# Altium schematic sheet HW4.SchDoc(HW4)
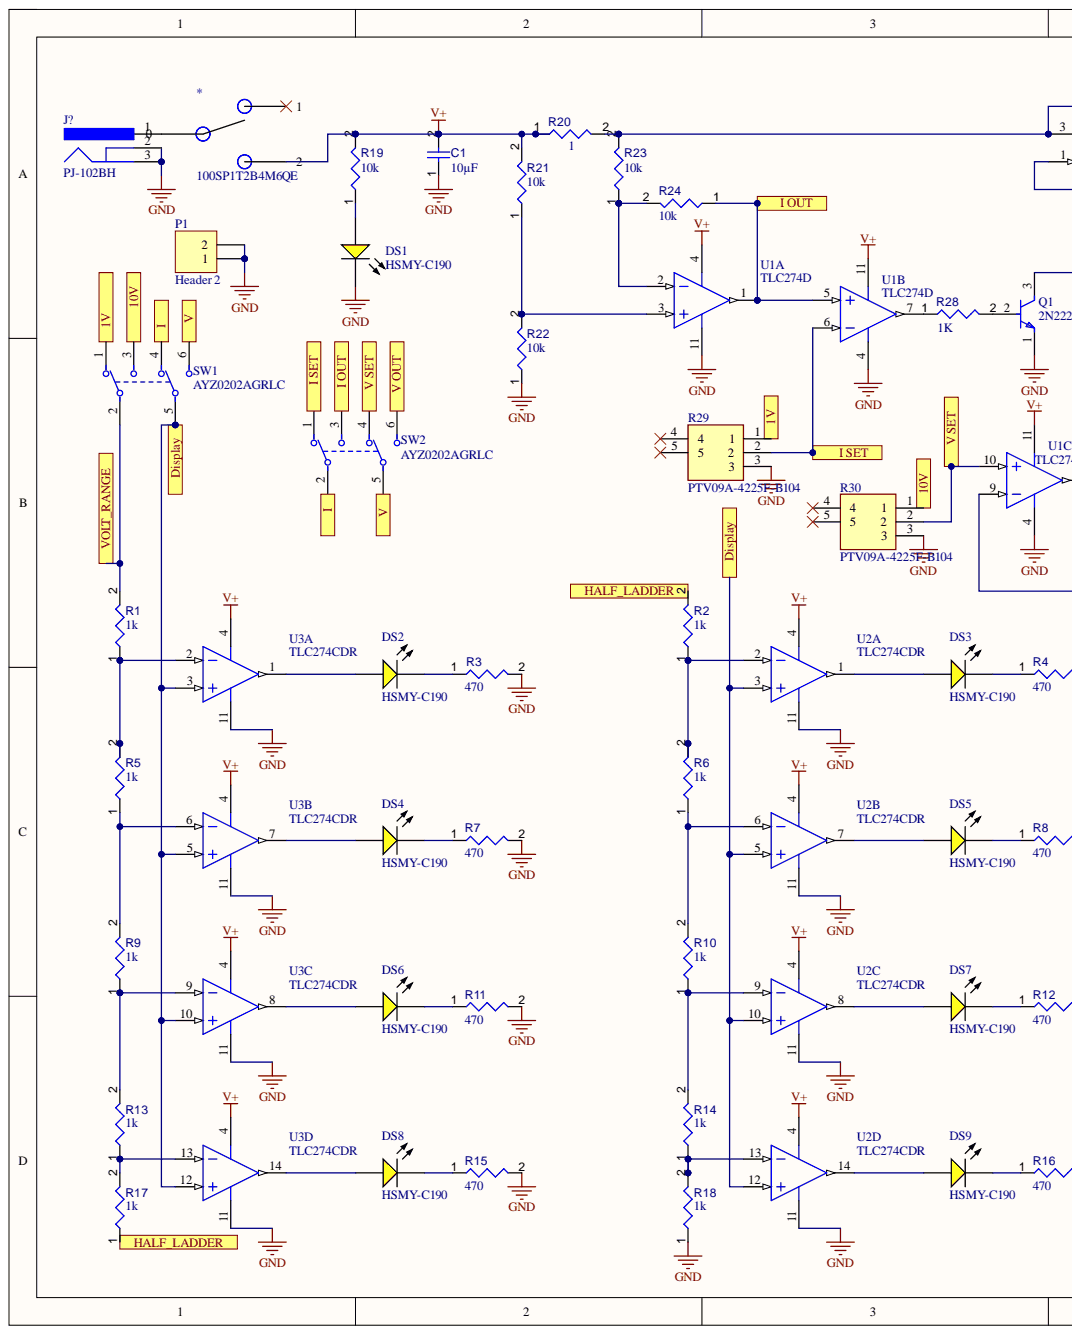
[diagram p0: HW4.SchDoc, left]
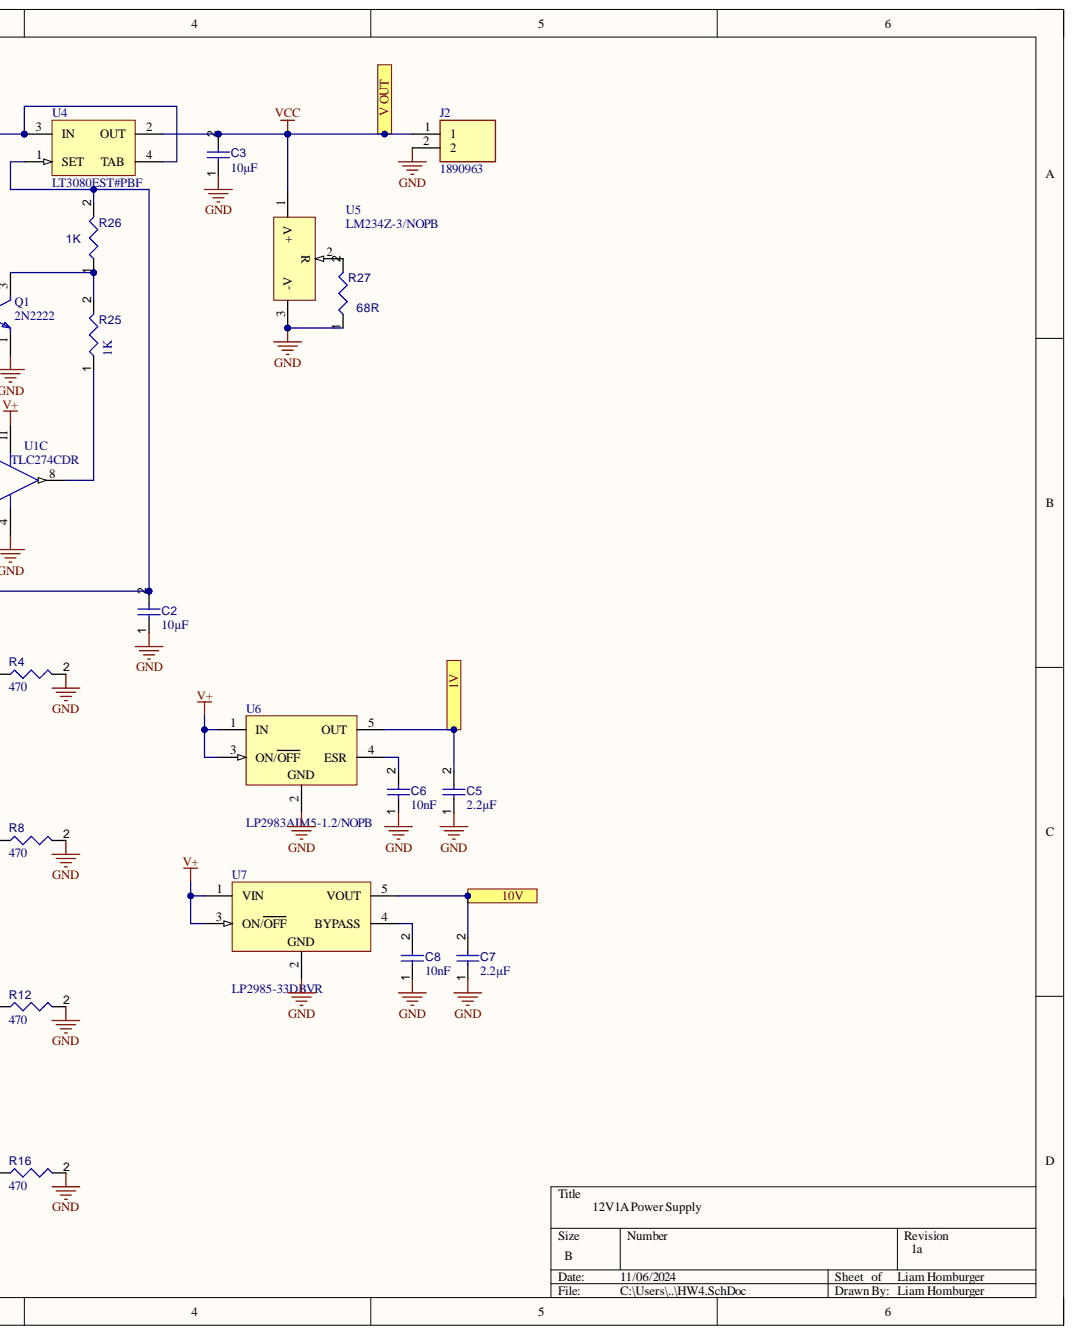
[diagram p1: HW4.SchDoc, right]

## Parts
*  100SP1T2B4M6QE  [100SP1T2B4M6QE-Footprint-1]
C1  value 10µF  [FP-0805-L_2_0_0_2-W_1_25-MFG]  (hidden comment: GMK212BBJ106KG-T)
C2  value 10µF  [FP-0805-L_2_0_0_2-W_1_25-MFG]  (hidden comment: GMK212BBJ106KG-T)
C3  value 10µF  [FP-0805-L_2_0_0_2-W_1_25-MFG]  (hidden comment: GMK212BBJ106KG-T)
C5  value 2.2µF  [Capacitor 0805]  (hidden comment: VJ0805G225KXJTW1BC)
C6  10nf  [Capacitor 0805]
C7  value 2.2µF  [Capacitor 0805]  (hidden comment: VJ0805G225KXJTW1BC)
C8  10nf  [Capacitor 0805]
DS1  HSMY-C190  [AVAG-HSMX-C190_V]
DS2  HSMY-C190  [AVAG-HSMX-C190_V]
DS3  HSMY-C190  [AVAG-HSMX-C190_V]
DS4  HSMY-C190  [AVAG-HSMX-C190_V]
DS5  HSMY-C190  [AVAG-HSMX-C190_V]
DS6  HSMY-C190  [AVAG-HSMX-C190_V]
DS7  HSMY-C190  [AVAG-HSMX-C190_V]
DS8  HSMY-C190  [AVAG-HSMX-C190_V]
DS9  HSMY-C190  [AVAG-HSMX-C190_V]
J2  1890963  [1890963]
J?  PJ-102BH  [CUI-PJ-102BH_V]
P1  Header 2  [HDR1X2]
Q1  2N2222  [FP-JAN2N2222A-MFG]
R1  value 1k  [RESC2013X60X40LL20T25_A]  (hidden comment: ERA-6AEB103V)
R2  value 1k  [RESC2013X60X40LL20T25_A]  (hidden comment: ERA-6AEB103V)
R3  value 470  [RESC2013X50X30ML20T20]  (hidden comment: CRCW0805470RFKEA)
R4  value 470  [RESC2013X50X30ML20T20]  (hidden comment: CRCW0805470RFKEA)
R5  value 1k  [RESC2013X60X40LL20T25_A]  (hidden comment: ERA-6AEB103V)
R6  value 1k  [RESC2013X60X40LL20T25_A]  (hidden comment: ERA-6AEB103V)
R7  value 470  [RESC2013X50X30ML20T20]  (hidden comment: CRCW0805470RFKEA)
R8  value 470  [RESC2013X50X30ML20T20]  (hidden comment: CRCW0805470RFKEA)
R9  value 1k  [RESC2013X60X40LL20T25_A]  (hidden comment: ERA-6AEB103V)
R10  value 1k  [RESC2013X60X40LL20T25_A]  (hidden comment: ERA-6AEB103V)
R11  value 470  [RESC2013X50X30ML20T20]  (hidden comment: CRCW0805470RFKEA)
R12  value 470  [RESC2013X50X30ML20T20]  (hidden comment: CRCW0805470RFKEA)
R13  value 1k  [RESC2013X60X40LL20T25_A]  (hidden comment: ERA-6AEB103V)
R14  value 1k  [RESC2013X60X40LL20T25_A]  (hidden comment: ERA-6AEB103V)
R15  value 470  [RESC2013X50X30ML20T20]  (hidden comment: CRCW0805470RFKEA)
R16  value 470  [RESC2013X50X30ML20T20]  (hidden comment: CRCW0805470RFKEA)
R17  value 1k  [RESC2013X60X40LL20T25_A]  (hidden comment: ERA-6AEB103V)
R18  value 1k  [RESC2013X60X40LL20T25_A]  (hidden comment: ERA-6AEB103V)
R19  value 10k  [RESC2013X60X40LL20T25_A]  (hidden comment: ERA-6AEB103V)
R20  value 1  [FP-MCU0805-MFG]  (hidden comment: MCU08050Z0000ZP500)
R21  value 10k  [RESC2013X60X40LL20T25_A]  (hidden comment: ERA-6AEB103V)
R22  value 10k  [RESC2013X60X40LL20T25_A]  (hidden comment: ERA-6AEB103V)
R23  value 10k  [RESC2013X60X40LL20T25_A]  (hidden comment: ERA-6AEB103V)
R24  value 10k  [RESC2013X60X40LL20T25_A]  (hidden comment: ERA-6AEB103V)
R25  1 Kohm 0805  [Chip2PinSM127P600-8N]  (value not drawn)
R26  1K  [Chip2PinSM127P600-8N]
R27  68R  [Chip2PinSM127P600-8N]
R28  1 Kohm 0805  [Chip2PinSM127P600-8N]  (value not drawn)
R29  PTV09A-4225F-B104  [PTV09A4020FB103]
R30  PTV09A-4225F-B104  [PTV09A4020FB103]
SW1  AYZ0202AGRLC  [FP-AYZ0202AGRLC-MFG]
SW2  AYZ0202AGRLC  [FP-AYZ0202AGRLC-MFG]
U1  TLC274D  [D0014A_L]  (parts A,B,C)
U2  TLC274CDR  [D0014A_L]  (parts A,B,C,D)
U3  TLC274CDR  [D0014A_L]  (parts A,B,C,D)
U4  LT3080EST#PBF  [LT-ST-3-SOT-223_M]
U5  LM234Z-3/NOPB  [LP0003A]
U6  LP2983AIM5-1.2/NOPB  [MF05A_M]
U7  LP2985-33DBVR  [DBV0005A_L]

## Ports
1V, 10V, Display, HALF_LADDER, I, I OUT, I SET, V, V OUT, V SET, VOLT_RANGE

## Nets
GND: C1.1 C2.1 C3.1 C5.1 C6.1 C7.1 C8.1 DS1.2 J2.2 J?.2 J?.3 P1.1 P1.2 Q1.1 R3.2 R4.2 R7.2 R8.2 R11.2 R12.2 R15.2 R16.2 R18.1 R22.1 R27.1 R29.3 R30.3 U1.4 U1.11 U2.11(V+) U3.11(V+) U5.3 U6.2 U7.2
V+: C1.2 R19.2 R20.1 R21.2 U1.4 U1.11 U2.4 U3.4 U6.1(IN) U6.3(ON/OFF) U7.1(VIN) U7.3(ON/OFF)
1V: C5.2 R29.1 SW1.1 U6.5(OUT) ; port 1V
10V: C7.2 R30.1 SW1.3 U7.5(VOUT) ; port 10V
Display: SW1.5 U2.3 U2.5 U2.10 U2.12 U3.3 U3.5 U3.10 U3.12 ; port Display
HALF_LADDER: R2.2 R17.1 ; port HALF_LADDER
I: SW1.4 SW2.2 ; port I
I OUT: R24.1 SW2.3 U1.1 U1.5 ; port I OUT
I SET: R29.2 SW2.1 U1.6 ; port I SET
V: SW1.6 SW2.5 ; port V
V SET: R30.2 SW2.4 U1.10 ; port V SET
VCC: C3.2 J2.1 SW2.6 U4.2(OUT) U5.1 ; port V OUT
VOLT_RANGE: R1.2 SW1.2 ; port VOLT_RANGE
NetC2_2: C2.2 R26.2 U1.9 U4.1(SET)
NetC6_2: C6.2 U6.4(ESR)
NetC8_2: C8.2 U7.4(BYPASS)
NetDS1_1: DS1.1 R19.1
NetDS2_1: DS2.1 U3.1
NetDS2_2: DS2.2 R3.1
NetDS3_1: DS3.1 U2.1
NetDS3_2: DS3.2 R4.1
NetDS4_1: DS4.1 U3.7
NetDS4_2: DS4.2 R7.1
NetDS5_1: DS5.1 U2.7
NetDS5_2: DS5.2 R8.1
NetDS6_1: DS6.1 U3.8
NetDS6_2: DS6.2 R11.1
NetDS7_1: DS7.1 U2.8
NetDS7_2: DS7.2 R12.1
NetDS8_1: DS8.1 U3.14
NetDS8_2: DS8.2 R15.1
NetDS9_1: DS9.1 U2.14
NetDS9_2: DS9.2 R16.1
NetQ1_2: Q1.2 R28.2
NetQ1_3: Q1.3 R25.2 R26.1
NetR1_1: R1.1 R5.2 U3.2
NetR2_1: R2.1 R6.2 U2.2
NetR5_1: R5.1 R9.2 U3.6
NetR6_1: R6.1 R10.2 U2.6
NetR9_1: R9.1 R13.2 U3.9
NetR10_1: R10.1 R14.2 U2.9
NetR13_1: R13.1 R17.2 U3.13
NetR14_1: R14.1 R18.2 U2.13
NetR20_2: R20.2 R23.2 U4.3(IN) U4.4(TAB)
NetR21_1: R21.1 R22.2 U1.3
NetR23_1: R23.1 R24.2 U1.2
NetR25_1: R25.1 U1.8
NetR27_2: R27.2 U5.2
NetR28_1: R28.1 U1.7

Not connected: * 0 1 2; J? 1; R29 4 5; R30 4 5

## Library data of the parts
* = CMP-011-00006-1: Toggle Switch SPDT; Current Rating: 5A; Throw Configuration: Dual; Voltage Rating: 28V; Case/Package: SP100; Component Kind: Standard; Max Current Rating: 5A; Pins: 3; Library Name: Liam Personal
C1-C3 = CMP-001-00008-1: Ceramic Capacitor, Multilayer, Ceramic, 35V, 10% +Tol, 10% -Tol, X5R, 15% TC, 10uF, Surface Mount, 0805; Capacitor Type: Ceramic; Case/Package: 0805; Component Kind: Standard; Max Operating Temperature: 85°C; Min Operating Temperature: -55°C; Mounting Technology: Surface Mount; Pins: 2; RoHS Compliant: Yes; Library Name: Liam Personal
C5-C8 = CMP-001-00007-1: Ceramic Capacitor, Multilayer, Ceramic, 16V, 10% +Tol, 10% -Tol, X5R, 15% TC, 2.2uF, Surface Mount, 0805; Capacitor Type: Ceramic; Case/Package: 0805; Component Kind: Standard; Max Operating Temperature: 85°C; Min Operating Temperature: -55°C; Mounting Technology: Surface Mount; Pins: 2; RoHS Compliant: Yes; Library Name: Liam Personal
  C6 C8: Value: 10nF
DS1-DS9 = CMP-007-00000-1: Single Color LED, Yellow, Untinted Diffused, 1mm; Forward Current: 20mA; Forward Voltage: 2.6V; Component Kind: Standard; Max Operating Temperature: 85°C; Min Operating Temperature: -40°C; Mounting Technology: Surface Mount; Pins: 2; RoHS Compliant: Yes; Library Name: Liam Personal
J2 = CMP-004-000000-2: 2 port screw terminal block; Manufacturer_Name: Phoenix Contact; Current Rating: 16A; Number of Decks: 1; Number of Ways: 2; Safety Approval: UL,VDE,CUL,GOST; Voltage Rating: 400V; Wire Cross Section: 2.5mm2; Wire/Cable Gauge: 14AWG; Arrow Part Number: 1890963; Arrow Price/Stock: https://www.arrow.com/en/products/1890963/phoenix-contact?region=nac; Component Kind: Standard; Datasheet Link: http://www.phoenixcontact.com/de/produkte/1890963/pdf; Design Item ID: 1890963; Fastening Method: SCREW; Height: 15.15mm; Manufacturer_Part_Number: 1890963; Mounting Technology: Board; Mouser Part Number: 651-1890963; Mouser Price/Stock: https://www.mouser.co.uk/ProductDetail/Phoenix-Contact/1890963?qs=5W%252BNKB25SvKX6KIA0xFJOA%3D%3D; Number of Rows: 1; Reach SVHC Compliant: No; RoHS Compliant: Yes; Source: SamacSys.SchLib; Terminal and Terminal Block Type: MODULAR TERMINAL BLOCK; Library Name: Liam Personal
J? = CMP-00030-00001-1: DC Power Plug or Jack; Component Kind: Standard; Library Name: Liam Personal
P1 = CMP-002-00098-1: Header, 2-Pin; Component Kind: Standard; Component Type: Standard; Design Item ID: Header 2; LatestRevisionDate: 17-Jul-2002; LatestRevisionNote: Re-released for DXP Platform.; Pins: 2; Signal Integrity: Connector; Source: Miscellaneous Connectors.SchLib; Library Name: Liam Personal; Publisher: Altium Limited
Q1 = CMP-013-00004-1: Small Signal Bipolar Transistor, 0.8A I(C), 50V V(BR)CEO, 1-Element, NPN, Silicon, TO-206AA; Component Kind: Standard; Library Name: Liam Personal
R1 R2 R5 R6 R9 R10 R13 R14 R17-R19 R21-R24 = CMP-009-00001-1: Fixed Resistor, Thin Film, 0.125W, 10000ohm, 100V, 0.1% +/-Tol, 25ppm/Cel, Surface Mount, 0805; Power: 125mW; Case/Package: 0805; Component Kind: Standard; Max Operating Temperature: 155°C; Min Operating Temperature: -55°C; Mounting Technology: Surface Mount; Pins: 2; RoHS Compliant: Yes; Tolerance: 0.1%; Library Name: Liam Personal
R3 R4 R7 R8 R11 R12 R15 R16 = CMP-009-00015-1: Fixed Resistor, Metal Glaze/thick Film, 0.125W, 470ohm, 150V, 1% +/-Tol, 100ppm/Cel, Surface Mount, 0805; Power: 125mW; Case/Package: 0805; Component Kind: Standard; Max Operating Temperature: 155°C; Min Operating Temperature: -55°C; Mounting Technology: Surface Mount; Pins: 2; RoHS Compliant: Yes; Tolerance: 1%; Library Name: Liam Personal
R20 = CMP-009-00018-1: Fixed Resistor, Thin Film, 0.125W, 0ohm, Surface Mount, 0805; Power: 125mW; Case/Package: 0805; Component Kind: Standard; Max Operating Temperature: 125°C; Min Operating Temperature: -55°C; Mounting Technology: Surface Mount; Pins: 2; RoHS Compliant: Yes; Library Name: Liam Personal
R25-R28 = CMP-009-00008-3: Res Thin Film 0805 1K Ohm 0.1% 0.25W(1/4W) ±25ppm/C Sulfur Resistant Pad SMD Automotive T/R; Component Kind: Standard; Library Name: Liam Personal
R29 R30 = PTV09A-4225F-B104: Resistor; Manufacturer_Name: Bourns; Arrow Part Number: PTV09A-4225F-B104; Arrow Price/Stock: https://www.arrow.com/en/products/ptv09a-4225f-b104/bourns?region=nac; Component Kind: Standard; Datasheet Link: https://www.bourns.com/docs/product-datasheets/PTV09.pdf; Height: 20mm; Manufacturer_Part_Number: PTV09A-4225F-B104; Mouser Part Number: 652-PTV09A-4225FB104; Mouser Price/Stock: https://www.mouser.co.uk/ProductDetail/Bourns/PTV09A-4225F-B104?qs=Qzws7J6gxqyxh%252BD4YdlzTg%3D%3D
SW1 SW2 = CMP-011-00008-1: Slide Switch, 2 Positions, DPDT, On-on, Latched, 0.1A, 12VDC, Solder Terminal, Surface Mount-straight; Component Kind: Standard; Max Operating Temperature: 60°C; Min Operating Temperature: -10°C; Mounting Technology: Surface Mount; RoHS Compliant: Yes; Library Name: Liam Personal
U1-U3 = CMP-014-00002-1: Operational Amplifier, 4 Func, 12000uV Offset-Max, CMOS, PDSO14; Channels: 4; Nominal Supply Voltage: 5V; Case/Package: SOP; Component Kind: Standard; Max Operating Temperature: 70°C; Min Operating Temperature: 0°C; Pins: 14; RoHS Compliant: Yes; Library Name: Liam Personal
U4 = CMP-019-00006-1: Adjustable Positive LDO Regulator, 0V Min, 36V Max, 0.5V Dropout, BIPolar, PDSO4; Case/Package: SOP; Component Kind: Standard; Pins: 3; RoHS Compliant: Yes; Library Name: Liam Personal
U5 = CMP-019-00005-1: Analog Resistance Sensor, 0.001V Min, 10V Max, 6Cel, Round, Through Hole Mount; Max Supply Voltage: 40V; Min Supply Voltage: 1V; Component Kind: Standard; Max Operating Temperature: 100°C; Min Operating Temperature: -25°C; Mounting Technology: Through Hole; RoHS Compliant: Yes; Library Name: Liam Personal
U6 = CMP-019-00007-1: Fixed Positive Standard Regulator, 1.2VBIPolar, PDSO5; Max Supply Voltage: 16V; Case/Package: LSSOP; Component Kind: Standard; Pins: 5; RoHS Compliant: Yes; Library Name: Liam Personal
U7 = CMP-019-00008-1: Fixed Positive LDO Regulator, 3.3V, 0.575V Dropout, BIPolar, PDSO5; Max Supply Voltage: 16V; Case/Package: LSSOP; Component Kind: Standard; Pins: 5; RoHS Compliant: Yes; Library Name: Liam Personal
